# Altium schematic sheet 2.WIZ750JR_IC+_PHY.SchDoc
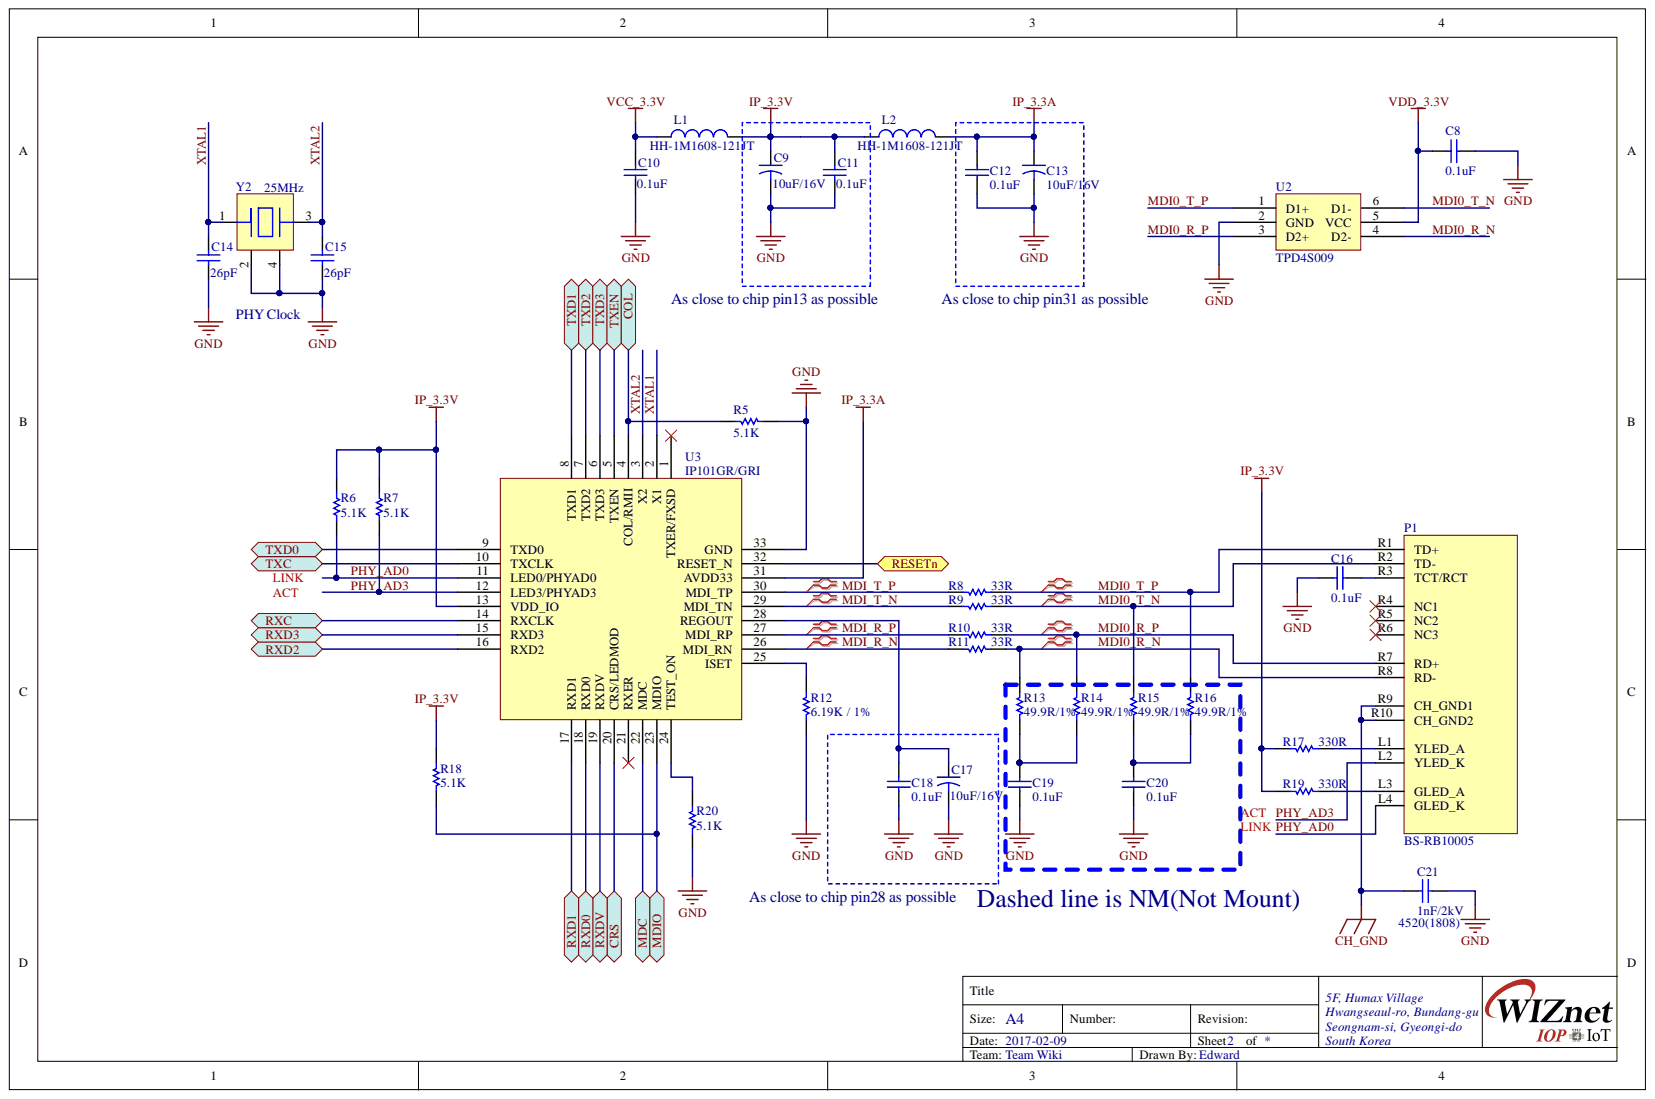
[diagram p0: 2.WIZ750JR_IC+_PHY.SchDoc]

## Parts
C8  0.1uF  [CAP-0402]
C9  10uF/16V  [CAP-A Size]
C10  0.1uF  [CAP-0402]
C11  0.1uF  [CAP-0402]
C12  0.1uF  [CAP-0402]
C13  10uF/16V  [CAP-A Size]
C14  26pF  [CAP-0402]
C15  26pF  [CAP-0402]
C16  0.1uF  [CAP-0402]
C17  10uF/16V  [CAP-A Size]
C18  0.1uF  [CAP-0402]
C19  0.1uF  [CAP-0402]
C20  0.1uF  [CAP-0402]
C21  1nF/2kV  [CAP-1808]
L1  HH-1M1608-121JT  [IND-0603]
L2  HH-1M1608-121JT  [IND-0603]
P1  BS-RB10005  [RJ45-125BAG1A]
R5  5.1K  [RES-0402]
R6  5.1K  [RES-0402]
R7  5.1K  [RES-0402]
R8  33R  [RES-0402]
R9  33R  [RES-0402]
R10  33R  [RES-0402]
R11  33R  [RES-0402]
R12  6.19K / 1%  [RES-0402]
R13  49.9R/1%  [RES-0402]
R14  49.9R/1%  [RES-0402]
R15  49.9R/1%  [RES-0402]
R16  49.9R/1%  [RES-0402]
R17  330R  [RES-0402]
R18  5.1K  [RES-0402]
R19  330R  [RES-0402]
R20  5.1K  [RES-0402]
U2  TPD4S009  [SOT-23-6L]
U3  IP101GR/GRI  [QFN32(0.4/4X4)]
Y2  25MHz  [OSC-82J0626]

## Ports
COL, CRS, MDC, MDIO, RESETN, RXC, RXD0, RXD1, RXD2, RXD3, RXDV, TXC, TXD0, TXD1, TXD2, TXD3, TXEN

## Nets
CH_GND: C21.2 P1.R9(CH_GND1) P1.R10(CH_GND2)
GND: C8.1 C9.2 C10.1 C11.1 C12.1 C13.2 C14.1 C15.1 C16.2 C17.2 C18.1 C19.1 C20.1 C21.1 R5.1 R12.1 R20.1 U2.2(GND) U3.33(GND) Y2.2 Y2.4
IP_3.3A: C12.2 C13.1 L2.2 U3.31(AVDD33)
IP_3.3V: C9.1 C11.2 L1.2 L2.1 R6.2 R7.2 R17.1 R18.2 R19.1 U3.13(VDD_IO)
VCC_3.3V: C10.2 L1.1
VDD_3.3V: C8.2 U2.5(VCC)
COL: R5.2 U3.4(COL/RMII) ; port COL
CRS: U3.20(CRS/LEDMOD) ; port CRS
MDC: U3.22(MDC) ; port MDC
MDI0_R_N: P1.R8(RD-) R11.1 R13.2 U2.4(D2-)
MDI0_R_P: P1.R7(RD+) R10.1 R14.2 U2.3(D2+)
MDI0_T_N: P1.R2(TD-) R9.1 R15.2 U2.6(D1-)
MDI0_T_P: P1.R1(TD+) R8.1 R16.2 U2.1(D1+)
MDI_R_N: R11.2 U3.26(MDI_RN)
MDI_R_P: R10.2 U3.27(MDI_RP)
MDI_T_N: R9.2 U3.29(MDI_TN)
MDI_T_P: R8.2 U3.30(MDI_TP)
MDIO: R18.1 U3.23(MDIO) ; port MDIO
PHY_AD0: P1.L4(GLED_K) R6.1 U3.11(LED0/PHYAD0)
PHY_AD3: P1.L2(YLED_K) R7.1 U3.12(LED3/PHYAD3)
RXC: U3.14(RXCLK) ; port RXC
RXD0: U3.18(RXD0) ; port RXD0
RXD1: U3.17(RXD1) ; port RXD1
RXD2: U3.16(RXD2) ; port RXD2
RXD3: U3.15(RXD3) ; port RXD3
RXDV: U3.19(RXDV) ; port RXDV
TXC: U3.10(TXCLK) ; port TXC
TXD0: U3.9(TXD0) ; port TXD0
TXD1: U3.8(TXD1) ; port TXD1
TXD2: U3.7(TXD2) ; port TXD2
TXD3: U3.6(TXD3) ; port TXD3
TXEN: U3.5(TXEN) ; port TXEN
XTAL1: C14.2 U3.2(X1) Y2.1
XTAL2: C15.2 U3.3(X2) Y2.3
NetC16_1: C16.1 P1.R3(TCT/RCT)
NetC17_1: C17.1 C18.2 U3.28(REGOUT)
NetC19_2: C19.2 R13.1 R14.1
NetC20_2: C20.2 R15.1 R16.1
NetP1_L1: P1.L1(YLED_A) R17.2
NetP1_L3: P1.L3(GLED_A) R19.2
NetR12_2: R12.2 U3.25(ISET)
NetR20_2: R20.2 U3.24(TEST_ON)
NetU3_32: U3.32(RESET_N) ; port RESETN

Not connected: P1 R4(NC1) R5(NC2) R6(NC3); U3 1(TXER/FXSD) 21(RXER)

## Library data of the parts
C8 C10-C12 C14-C16 C18-C20 = CAP-0402: Ceramic CAP 0402(1005); Component Kind: Standard; Library Name: Scott_lib.IntLib
C9 C13 C17 = CAP-A Size: Tantla CAP A Size EIA/IECQ 3216; Component Kind: Standard; Library Name: Scott_lib.IntLib
C21 = CAP-1808: Ceramic CAP 1808(4520); Component Kind: Standard; Library Name: Scott_lib.IntLib
L1 L2 = IND-0603: Inductor 0603(1608); Component Kind: Standard; Library Name: Scott_lib.IntLib
P1 = RB1-125BAG1A: 10/100 BASE-TX MAGJACK; Component Kind: Standard; Library Name: Edward.IntLib
R5 R8-R20 = RES-0402: Component Kind: Standard; Description: RES-0402; Library Name: Scott_lib.IntLib
R6 R7 = RES-0603: RES-0402; Component Kind: Standard; Library Name: Scott_lib.IntLib
U2 = tpd4s009: 6-PIN SOT DBVor DCK Package; Component Kind: Standard; Library Name: tpd4s009_SOT.SchLib
U3 = IP101GR/GRI: Pakage: VQFN-32; Component Kind: Standard; Part_name: IP101GR/GRI; Library Name: Edward.IntLib
Y2 = OSC-82J0626-25MHz: X-tal 25MHz,3.2x2.5 SMD, CL=18pF; Component Kind: Standard; Library Name: Scott_lib.IntLib
